# Altium schematic sheet MCU.SchDoc
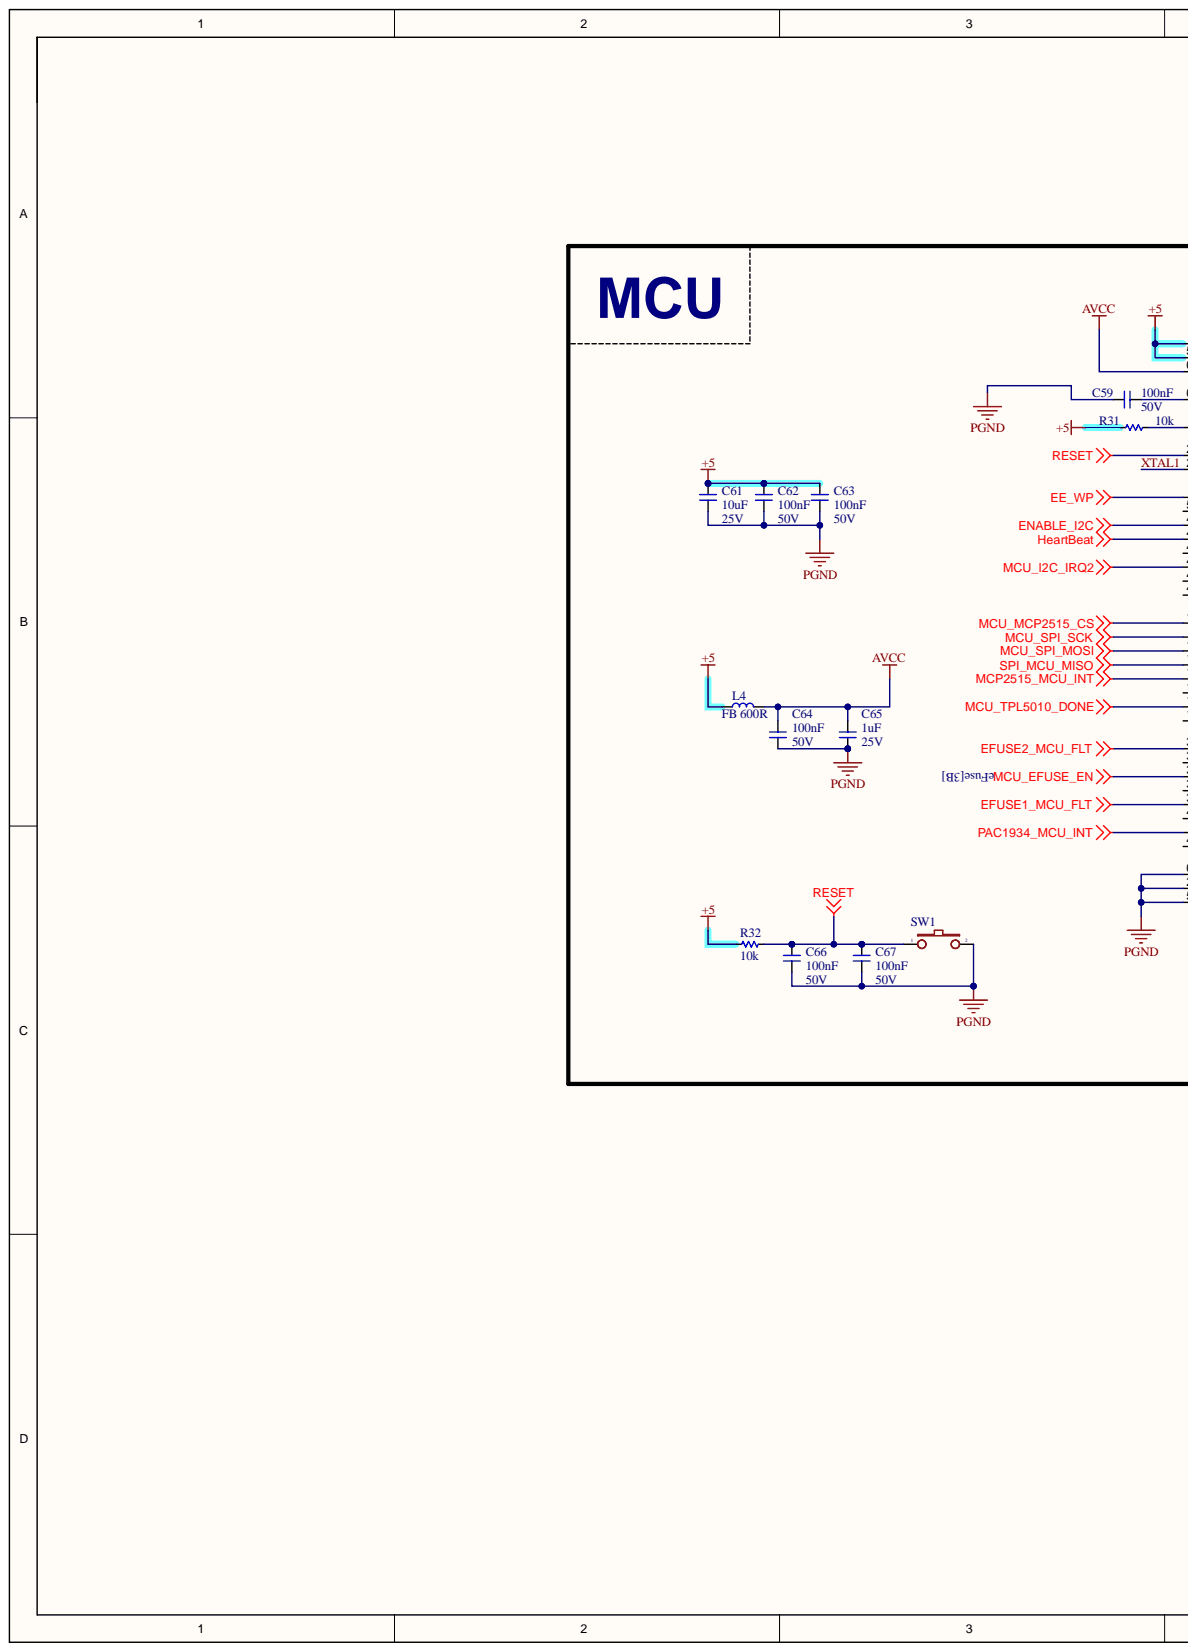
[diagram p0: MCU.SchDoc, left]
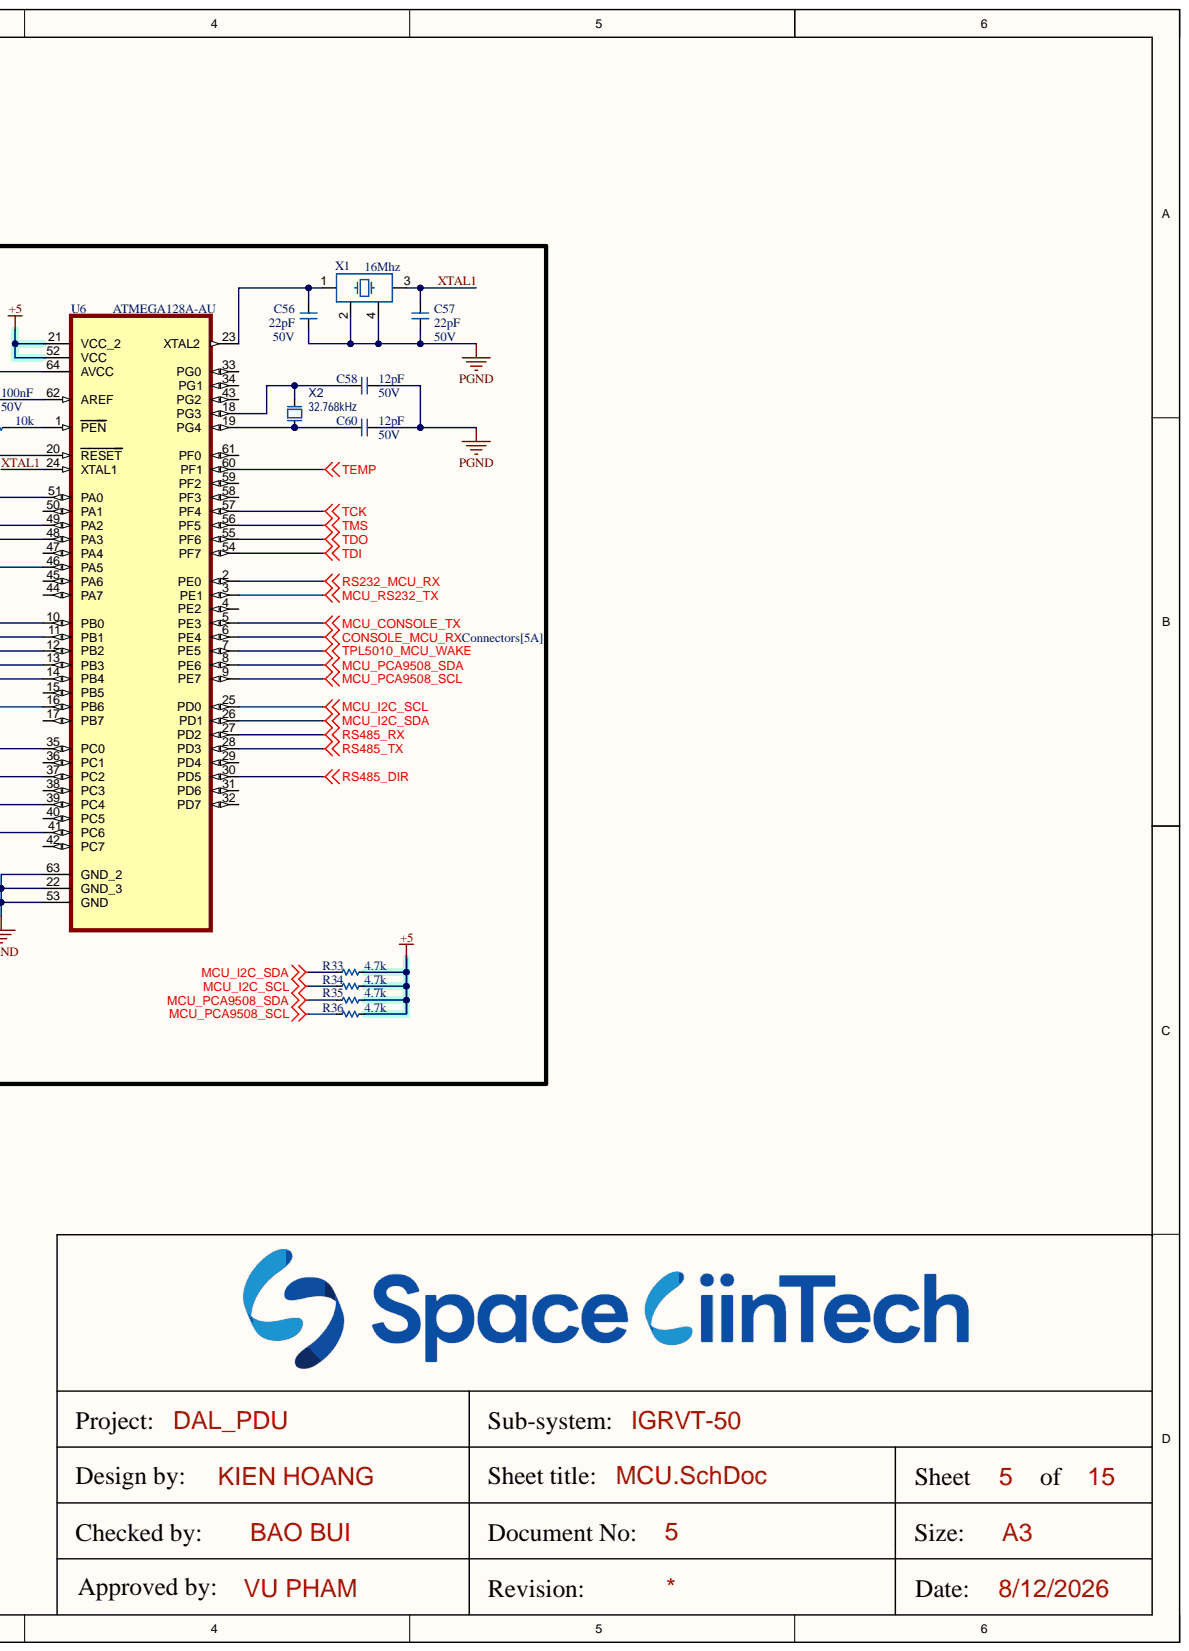
[diagram p1: MCU.SchDoc, right]

## Parts
C56  22pF  Voltage: 50V  [CAP_C_1608X80]
C57  22pF  Voltage: 50V  [CAP_C_1608X80]
C58  12pF  Voltage: 50V  [CAP_C_1608X80]
C59  100nF  Voltage: 50V  [CAP_C_1608X80]
C60  12pF  Voltage: 50V  [CAP_C_1608X80]
C61  10uF  Voltage: 25V  [CAP_C_1608X80]
C62  100nF  Voltage: 50V  [CAP_C_1608X80]
C63  100nF  Voltage: 50V  [CAP_C_1608X80]
C64  100nF  Voltage: 50V  [CAP_C_1608X80]
C65  1uF  Voltage: 25V  [CAP_C_1608X80]
C66  100nF  Voltage: 50V  [CAP_C_1608X80]
C67  100nF  Voltage: 50V  [CAP_C_1608X80]
L4  FB 600R  [L 0603 (1608)]
R31  10k  [RES_C_1608X60]
R32  10k  [RES_C_1608X60]
R33  4.7k  [RES_C_1608X60]
R34  4.7k  [RES_C_1608X60]
R35  4.7k  [RES_C_1608X60]
R36  4.7k  [RES_C_1608X60]
SW1  SW2  [SW1-SMD]  (value not drawn)
U6  ATMEGA128A-AU  [TQFP-64]
X1  16Mhz  [XTAL_2520]
X2  value 32.768kHz  [CRYSTAL_NX2012SA]  (hidden comment: Crystal 2 Pins)

## Nets
+5: C61.2 C62.1 C63.1 L4.1 R31.1 R32.1 R33.1 R34.1 R35.1 R36.1 U6.21(VCC_2) U6.52(VCC)
AVCC: C64.1 C65.1 L4.2 U6.64(AVCC)
CONSOLE_MCU_RX: U6.6(PE4)
EE_WP: U6.51(PA0)
EFUSE1_MCU_FLT: U6.39(PC4)
EFUSE2_MCU_FLT: U6.35(PC0)
ENABLE_I2C: U6.49(PA2)
HeartBeat: U6.48(PA3)
MCP2515_MCU_INT: U6.14(PB4)
MCU_CONSOLE_TX: U6.5(PE3)
MCU_EFUSE_EN: U6.37(PC2)
MCU_I2C_IRQ2: U6.46(PA5)
MCU_I2C_SCL: R34.2 U6.25(PD0)
MCU_I2C_SDA: R33.2 U6.26(PD1)
MCU_MCP2515_CS: U6.10(PB0)
MCU_PCA9508_SCL: R36.2 U6.9(PE7)
MCU_PCA9508_SDA: R35.2 U6.8(PE6)
MCU_RS232_TX: U6.3(PE1)
MCU_SPI_MOSI: U6.12(PB2)
MCU_SPI_SCK: U6.11(PB1)
MCU_TPL5010_DONE: U6.16(PB6)
PAC1934_MCU_INT: U6.41(PC6)
PGND: C56.1 C57.1 C58.1 C59.2 C60.1 C61.1 C62.2 C63.2 C64.2 C65.2 C66.1 C67.2 SW1.2 U6.22(GND_3) U6.53(GND) U6.63(GND_2) X1.2 X1.4
RESET: C66.2 C67.1 R32.2 SW1.1 U6.20(RESET)
RS232_MCU_RX: U6.2(PE0)
RS485_DIR: U6.30(PD5)
RS485_RX: U6.27(PD2)
RS485_TX: U6.28(PD3)
SPI_MCU_MISO: U6.13(PB3)
TCK: U6.57(PF4)
TDI: U6.54(PF7)
TDO: U6.55(PF6)
TEMP: U6.60(PF1)
TMS: U6.56(PF5)
TPL5010_MCU_WAKE: U6.7(PE5)
XTAL1: C57.2 U6.24(XTAL1) X1.3
NetC56_2: C56.2 U6.23(XTAL2) X1.1
NetC58_2: C58.2 U6.18(PG3) X2.2
NetC59_1: C59.1 U6.62(AREF)
NetC60_2: C60.2 U6.19(PG4) X2.1
NetR31_2: R31.2 U6.1(PEN)

Not connected: U6 4(PE2) 15(PB5) 17(PB7) 29(PD4) 31(PD6) 32(PD7) 33(PG0) 34(PG1) 36(PC1) 38(PC3) 40(PC5) 42(PC7) 43(PG2) 44(PA7) 45(PA6) 47(PA4) 50(PA1) 58(PF3) 59(PF2) 61(PF0)

## Library data of the parts
C56-C67 = _CAP_C_2: CAP; Component Kind: Standard; Library Name: LABLIB.SchLib
L4 = Inductor: Ferrite Bread; Component Kind: Standard; Library Name: chipfc_libs.SchLib
R31-R36 = _RES_B_2_2: RES; Component Kind: Standard; Library Name: LABLIB.SchLib
SW1 = SW2: Switch button 2 pin; Component Kind: Standard; Library Name: LABLIB.SchLib
X1 = crystal_4pin: Component Kind: Standard; Library Name: KIENASIY123_LIB.IntLib
X2 = OSC_32.768kHz: Crystal; Manufacturer 1: NDK; Manufacturer Part Number 1: NX2012SA-32.768K-STD-MUB-1; Description_: CRYSTAL 32.768kHz 12.5pF SMD NX2012SA; Dev Tools Status: Production; Load Capacitance: 12.5pF; MCHP-ID: OSC1092; Owner: Jamus Griego; Populated: YES; Tolerance: ±20ppm; Category: Crystal/Oscillator; Component Kind: Standard; ComponentLink1Description: SVN Datasheet; ComponentLink1URL: https://chn-vm-apps.microchip.com/mchprepo/parts/Datasheets/c_NX2012SA-STD-MUB-1_e.pdf; ComponentLink2Description: Local Datasheet; ComponentLink3Description: Open Symbol; ComponentLink4Description: Open Footprint; ComponentLink5Description: Open Footprint 2; ComponentLink6Description: Open Footprint 3; ComponentLink7Description: Open Footprint 4; Quantity Override: 1; Revision: 1; Status: MCL Design; Supplier 1: Digi-Key; Supplier Part Number 1: 644-1219-1-ND; Symbol: Crystal 2 Pins; Type: Crystal; ComponentLink2URL: C:\ALTIUM_WORK\00001_MCHP-CDB\Datasheets\c_NX2012SA-STD-MUB-1_e.pdf; ComponentLink3URL: C:\ALTIUM_WORK\00001_MCHP-CDB\Library\LibraryRefs\Crystal 2 Pins.SchLib; ComponentLink4URL: C:\ALTIUM_WORK\00001_MCHP-CDB\Library\Footprints\CRYSTAL_NX2012SA.PcbLib; Library Name: LABLIB.SchLib
U6 = ATMEGA128A-AU: Component Kind: Standard; Library Name: KIENASIY123_LIB.IntLib
